# Altium schematic sheet MainInterface.SchDoc
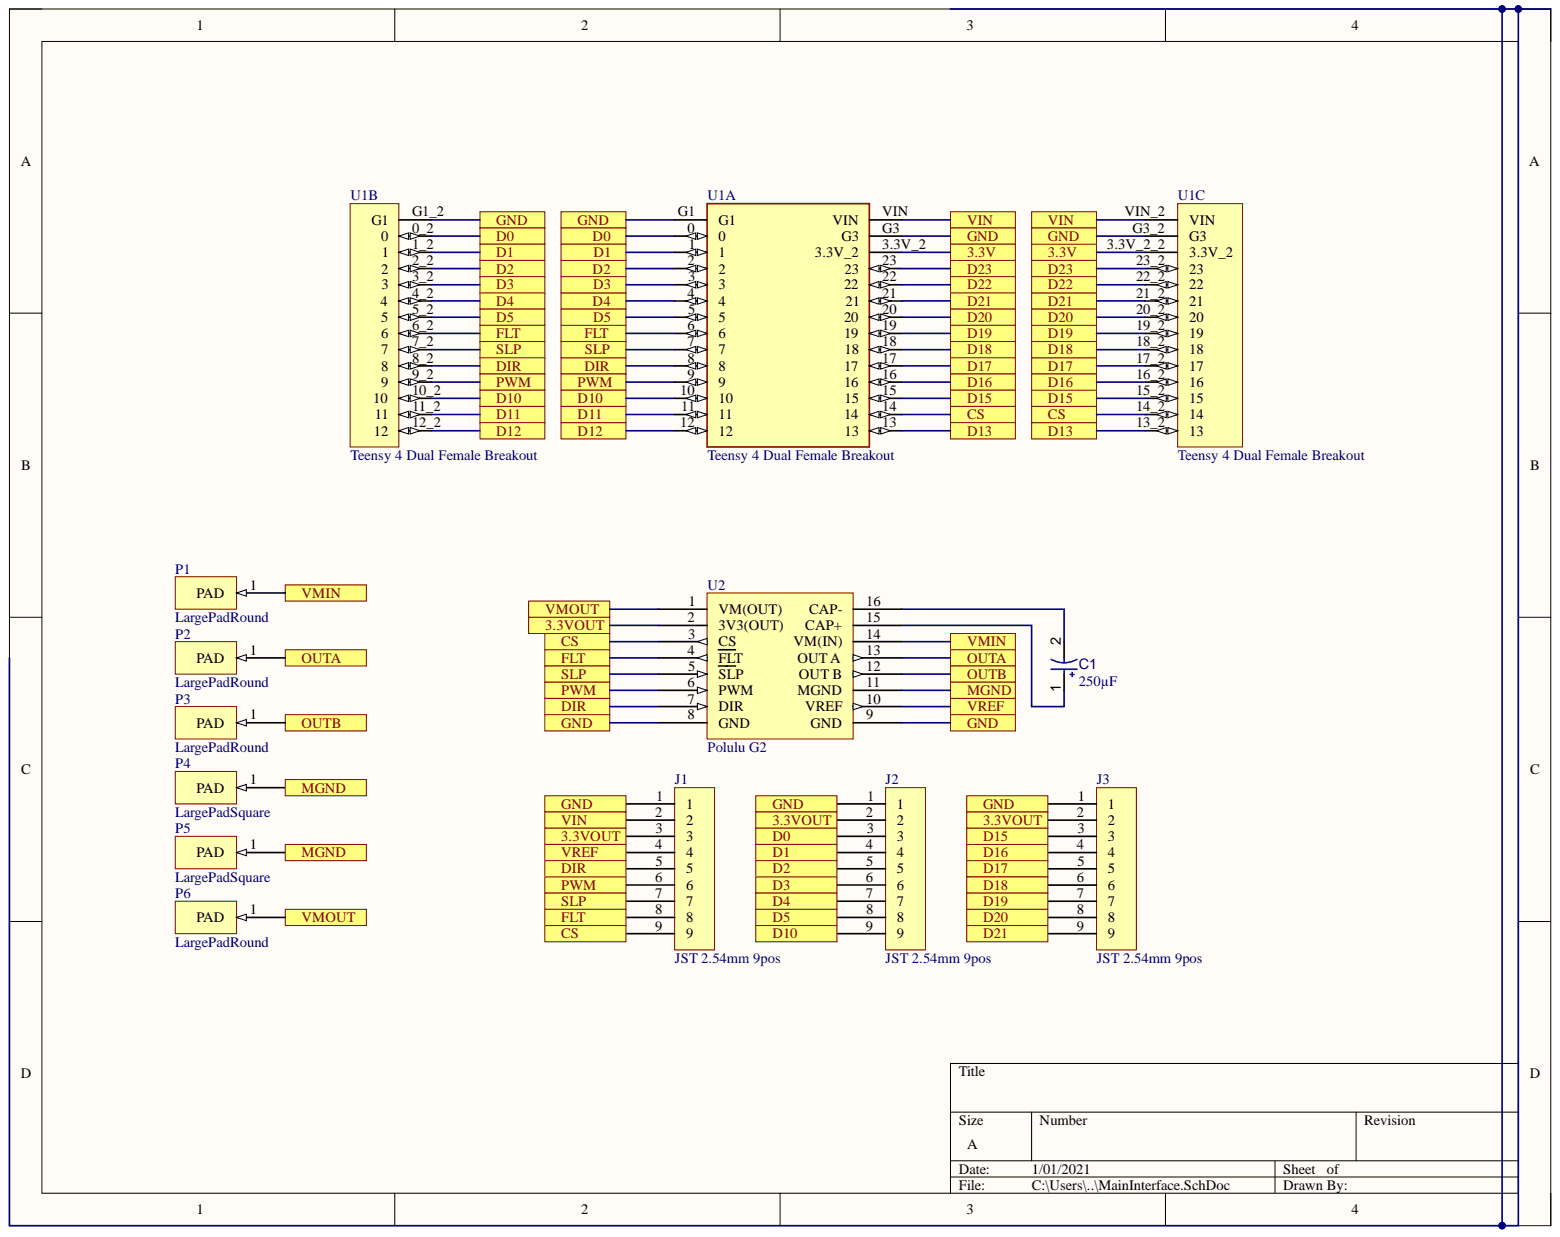
[diagram p0: MainInterface.SchDoc]

## Parts
C1  value 250µF  [CAPPR65-500-1600X1000X1700]  (hidden comment: ECA-2AM101)
J1  JST 2.54mm 9pos  [JST 2.54mm 9pos-Footprint-1]
J2  JST 2.54mm 9pos  [JST 2.54mm 9pos-Footprint-1]
J3  JST 2.54mm 9pos  [JST 2.54mm 9pos-Footprint-1]
P1  LargePadRound  [LargePadRound-Footprint-1]
P2  LargePadRound  [LargePadRound-Footprint-1]
P3  LargePadRound  [LargePadRound-Footprint-1]
P4  LargePadSquare  [LargePad-Footprint-1]
P5  LargePadSquare  [LargePad-Footprint-1]
P6  LargePadRound  [LargePadRound-Footprint-1]
U1  Teensy 4 Dual Female Breakout  [Teensy 4 Dual Female Breakout-Footprint-1]  (parts A,B,C)
U2  Polulu G2  [Polulu G2-Footprint-1]

## Ports
3.3V, 3.3VOUT, CS, D0, D1, D2, D3, D4, D5, D10, D11, D12, D13, D15, D16, D17, D18, D19, D20, D21, D22, D23, DIR, FLT, GND, MGND, OUTA, OUTB, PWM, SLP, VIN, VMIN, VMOUT, VREF

## Nets
3.3V: U1.3.3V_2 U1.3.3V_2_2(3.3V_2) ; port 3.3V
3.3VOUT: J1.3 J2.2 J3.2 U2.2(3V3(OUT)) ; port 3.3VOUT
CS: J1.9 U1.14 U1.14_2 U2.3(CS) ; port CS
D0: J2.3 U1.0 U1.0_2 ; port D0
D1: J2.4 U1.1 U1.1_2 ; port D1
D2: J2.5 U1.2 U1.2_2 ; port D2
D3: J2.6 U1.3 U1.3_2 ; port D3
D4: J2.7 U1.4 U1.4_2 ; port D4
D5: J2.8 U1.5 U1.5_2 ; port D5
D10: J2.9 U1.10 U1.10_2 ; port D10
D11: U1.11 U1.11_2 ; port D11
D12: U1.12 U1.12_2 ; port D12
D13: U1.13 U1.13_2 ; port D13
D15: J3.3 U1.15 U1.15_2 ; port D15
D16: J3.4 U1.16 U1.16_2 ; port D16
D17: J3.5 U1.17 U1.17_2 ; port D17
D18: J3.6 U1.18 U1.18_2 ; port D18
D19: J3.7 U1.19 U1.19_2 ; port D19
D20: J3.8 U1.20 U1.20_2 ; port D20
D21: J3.9 U1.21 U1.21_2 ; port D21
D22: U1.22 U1.22_2 ; port D22
D23: U1.23 U1.23_2 ; port D23
DIR: J1.5 U1.8 U1.8_2 U2.7(DIR) ; port DIR
FLT: J1.8 U1.6 U1.6_2 U2.4(FLT) ; port FLT
GND: J1.1 J2.1 J3.1 U1.G1 U1.G1_2(G1) U1.G3 U1.G3_2(G3) U2.8(GND) U2.9(GND) ; port GND
MGND: P4.1 P5.1 U2.11(MGND) ; port MGND
OUTA: P2.1 U2.13(OUT_A) ; port OUTA
OUTB: P3.1 U2.12(OUT_B) ; port OUTB
PWM: J1.6 U1.9 U1.9_2 U2.6(PWM) ; port PWM
SLP: J1.7 U1.7 U1.7_2 U2.5(SLP) ; port SLP
VIN: J1.2 U1.VIN U1.VIN_2(VIN) ; port VIN
VMIN: P1.1 U2.14(VM(IN)) ; port VMIN
VMOUT: P6.1 U2.1(VM(OUT)) ; port VMOUT
VREF: J1.4 U2.10(VREF) ; port VREF
NetC1_1: C1.1 U2.15(CAP+)
NetC1_2: C1.2 U2.16(CAP-)

## Library data of the parts
C1 = CMP-001-00034-5: Aluminum Electrolytic Capacitor, 100 uF, +/- 20%, 100 V, -40 to 85 degC, 2-Pin THD, RoHS, Bulk; Manufacturer: Panasonic; Ripple Current: 350mA; Voltage Rating: 100V; Capacitor Type: Electrolytic; Case/Package: Radial; Component Kind: Standard; Datasheet URL: https://industrial.panasonic.com/cdbs/www-data/pdf/RDF0000/ABA0000C1047.pdf; Datasheet Version: Mar-16; Diameter: 10mm; Lead Pitch: 5mm; Manufacturer URL: http://www.panasonic.com/; Max Operating Temperature: 85°C; Min Operating Temperature: -40°C; Mounting Technology: TH; Package Description: 2-Pin Through Hole Device, Dia 10 mm, Body Length 16 mm; Package Quantity: 200; Package Reference: CAPPR65-500-1600X1000X1700; Packaging: Bulk; Pins: 2; Ripple Current (AC): 350mA; RoHS Compliant: True; Tolerance: 20%; Library Name: Gannon University
J1-J3 = CMP-002-00071-2: 9 Position 2.54mm pitch male JST; Component Kind: Standard; Library Name: Gannon University
P1-P3 P6 = CMP-000002-2: 3.5mm X 3.5mm round pad with 2.2mm hole; Component Kind: Standard; Library Name: Gannon University
P4 P5 = CMP-000001-2: 3.5mm X 3.5mm square pad with 2.2mm hole; Component Kind: Standard; Library Name: Gannon University
U1 = CMP-016-0001-2: Teensy 4.0 Microcontroller layout for dual-female SMT headers; Component Kind: Standard; Library Name: Gannon University
U2 = CMP-000000-2: Polulu G2 drive layout; Component Kind: Standard; Library Name: Gannon University
